# Altium schematic sheet MCU_Power.SchDoc(MCU_Power)
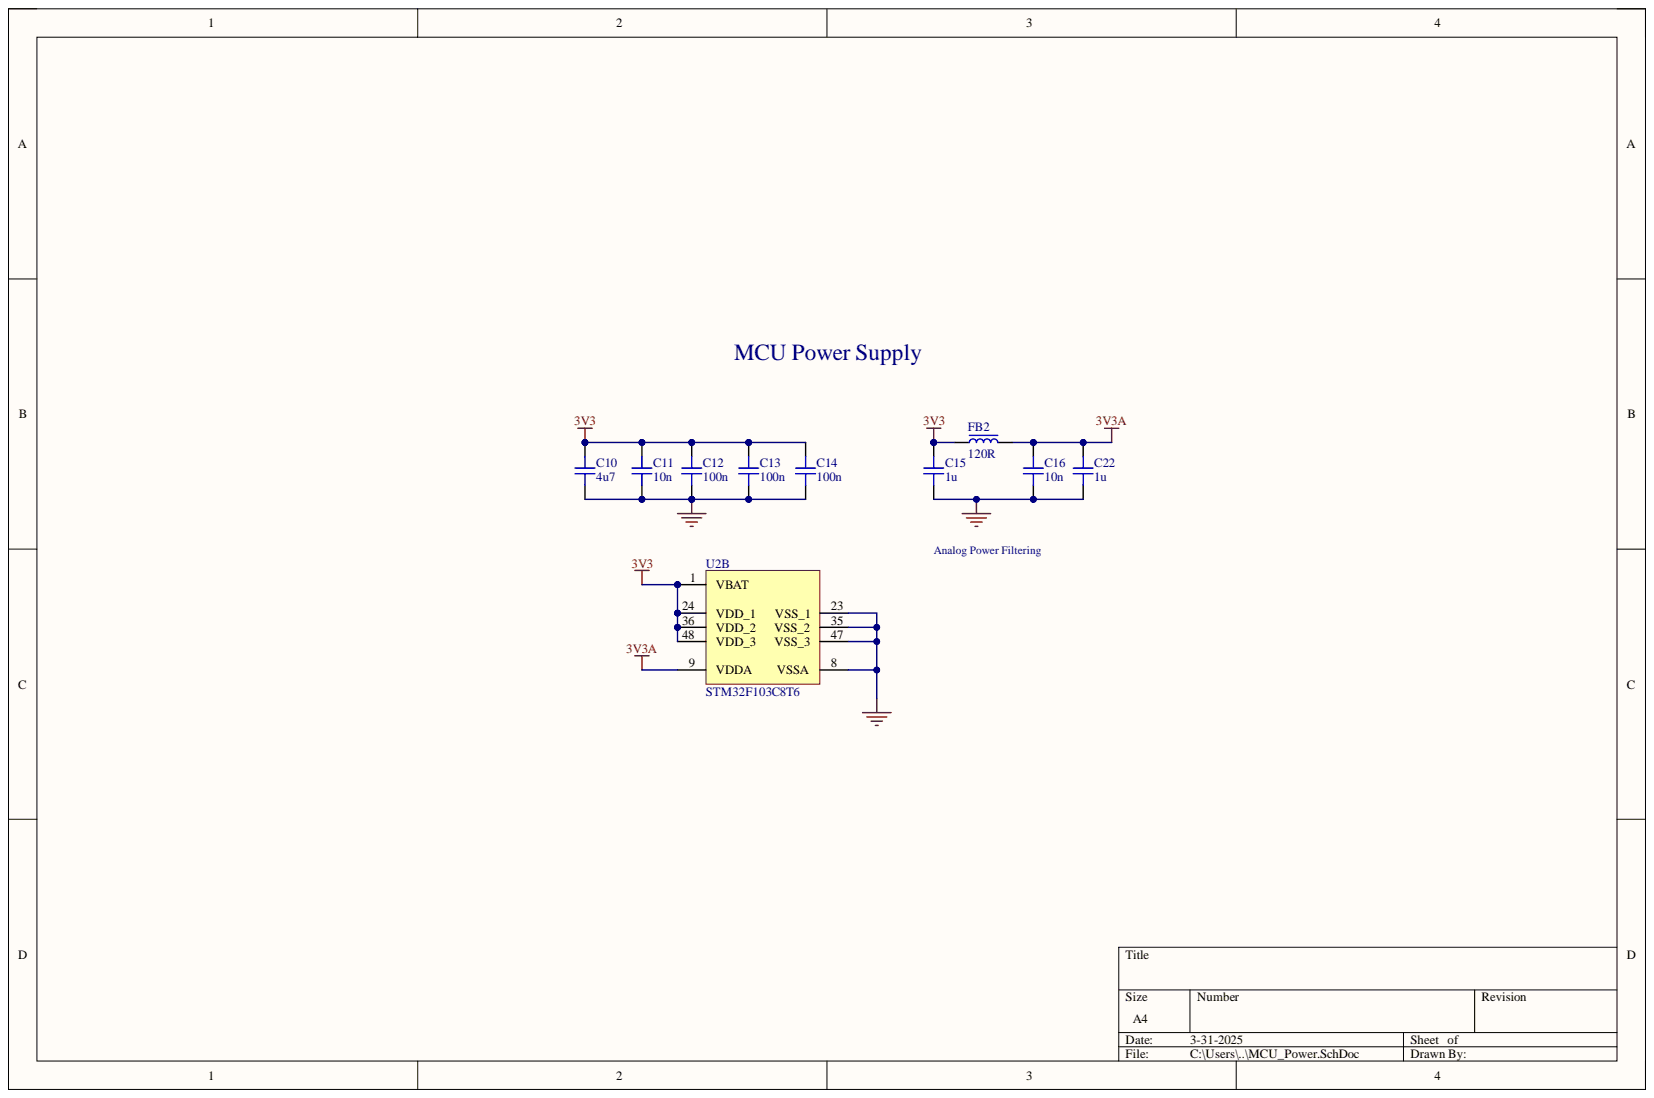
[diagram p0: MCU_Power.SchDoc]

## Parts
C10  4u7  [CAP_0603]
C11  10n  [CAP_0402]
C12  100n  [CAP_0402]
C13  100n  [CAP_0402]
C14  100n  [CAP_0402]
C15  1u  [CAP_0402]
C16  10n  [CAP_0402]
C22  1u  [CAP_0402]
FB2  120R  [FB_0603]
U2  STM32F103C8T6  [STM-LQFP48_L]  (part B)

## Nets
3V3: C10.2 C11.2 C12.2 C13.2 C14.2 C15.2 FB2.1 U2.1(VBAT) U2.24(VDD_1) U2.36(VDD_2) U2.48(VDD_3)
3V3A: C16.2 C22.2 FB2.2 U2.9(VDDA)
GND: C10.1 C11.1 C12.1 C13.1 C14.1 C15.1 C16.1 C22.1 U2.8(VSSA) U2.23(VSS_1) U2.35(VSS_2) U2.47(VSS_3)

## Library data of the parts
C10 = CAP_0603: Capacitor SMD 0603; Component Kind: Standard; Library Name: Schematic-Lib-PhilsLab.SchLib
C11-C16 C22 = CAP_0402: Capacitor SMD 0402; Component Kind: Standard; Library Name: Schematic-Lib-PhilsLab.SchLib
FB2 = FB_0603: Ferrite Bead SMD 0603; Component Kind: Standard; Library Name: Schematic-Lib-PhilsLab.SchLib
U2 = CMP-0237-00215-3: ARM Cortex-M3 32-bit MCU, 64 KB Flash, 20 KB Internal RAM, 37 I/Os, 48-pin LQFP, -40 to 85 degC, Tray; A/D Converter: 2x12-bit (10 Channel); FLASH Size (Prog) (kB): 64; I/Os (High Current): 37; Internal RAM Size (kB): 20; Operating Frequency(F) (Processor speed) (MHz): 72; Supply Voltage(Vcc) min (V): 2; Component Kind: Standard; ComponentLink1Description: Manufacturer URL; ComponentLink1URL: http://www.st.com/web/en/home.html; ComponentLink2Description: Datasheet; ComponentLink2URL: http://www.st.com/st-web-ui/static/active/en/resource/technical/document/datasheet/CD00161566.pdf; ComponentLink3Description: Package Specification; ComponentLink3URL: http://www.st.com/st-web-ui/static/active/en/resource/technical/document/datasheet/DM00058181.pdf; Core: ARM Cortex-M3; DatasheetVersion: Rev. 16; Library Name: Altium Content Vault; Mounting Technology: Surface Mount; PackageDescription: 48-Pin Low Profile Quad Flat Package, 7 x 7 mm Body, 0.5 mm Pitch; PackageReference: LQFP48; PackageVersion: Rev. 6, 06/2013; Packing: Tray; PartNumber: STM32F103C8T6
